# Altium schematic sheet digital_io.SchDoc
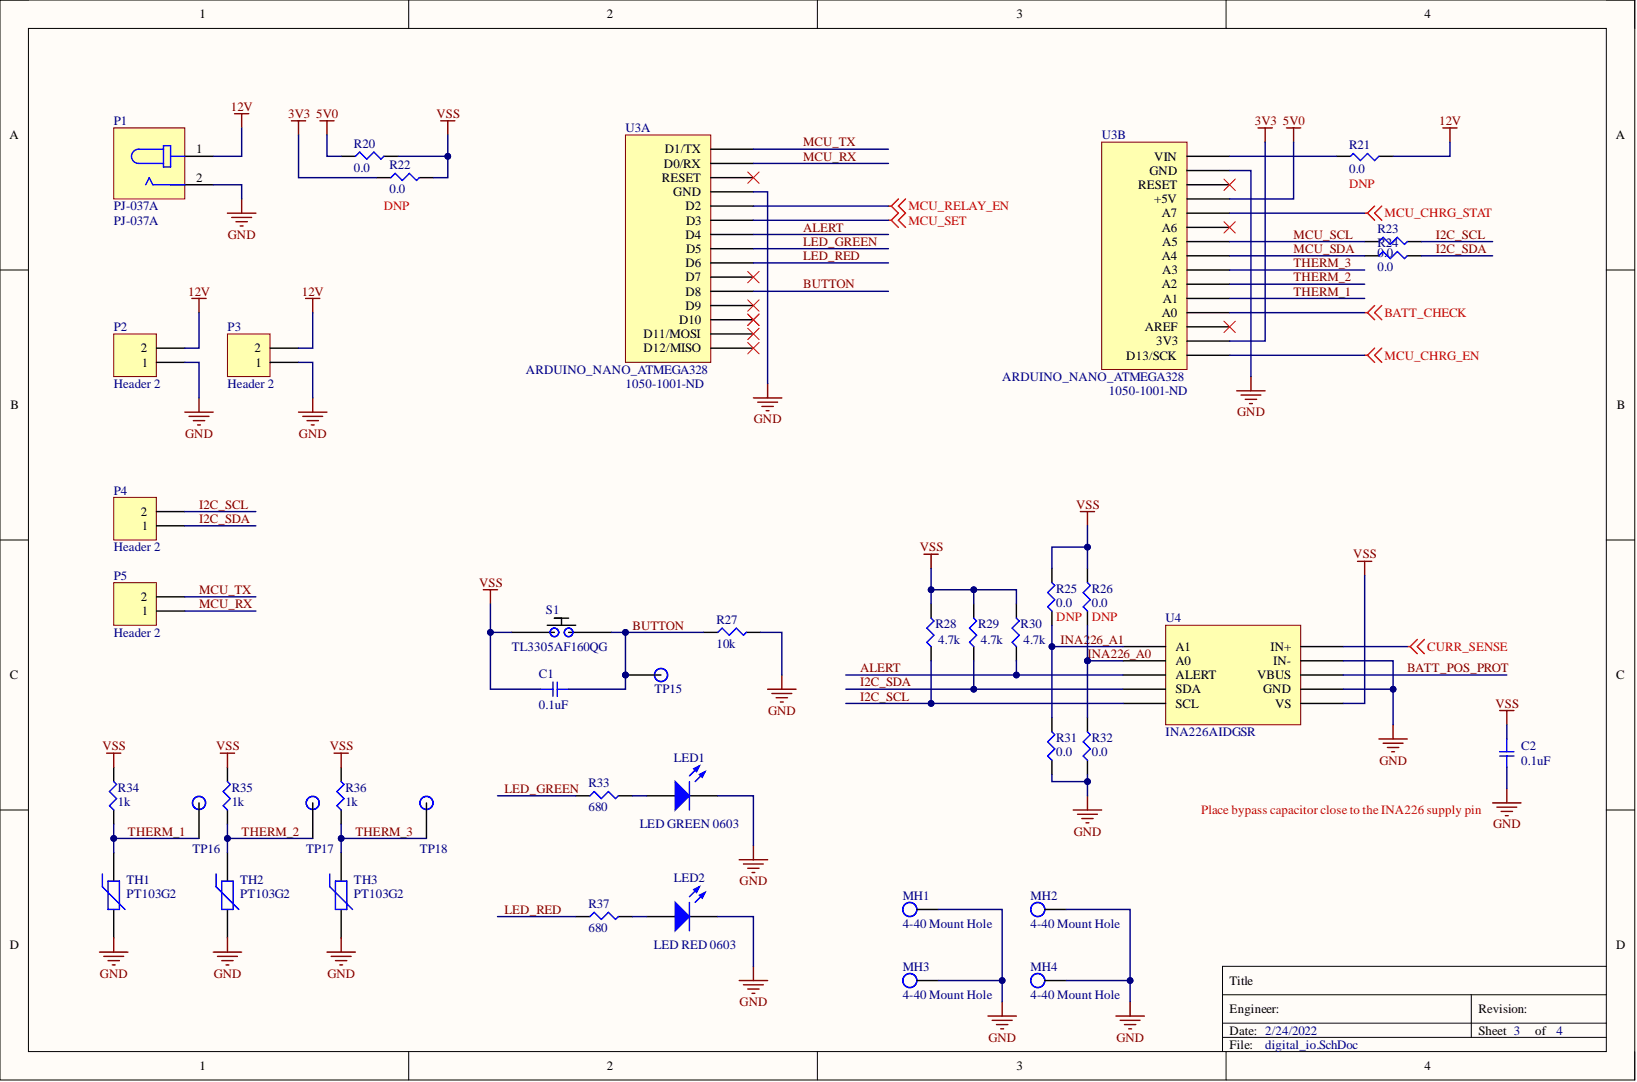
[diagram p0: digital_io.SchDoc]

## Parts
C1  0.1uF  [SMD-0805C]
C2  0.1uF  [SMD-0805C]
LED1  LED GREEN 0603  Color: GREEN  Package / Case: 0603  [LED 0603 GREEN]
LED2  LED RED 0603  Color: RED  Package / Case: 0603  [LED 0603 RED]
MH1  4-40 Mount Hole  [MH]
MH2  4-40 Mount Hole  [MH]
MH3  4-40 Mount Hole  [MH]
MH4  4-40 Mount Hole  [MH]
P1  PJ-037A  [CUI_PJ-037A]
P2  Header 2  [Pin Header 1x2 TH Pitch 2.54mm]
P3  Header 2  [Pin Header 1x2 TH Pitch 2.54mm]
P4  Header 2  [Pin Header 1x2 TH Pitch 2.54mm]
P5  Header 2  [Pin Header 1x2 TH Pitch 2.54mm]
R20  0.0  [SMD-0805-RES]
R21  0.0  [SMD-0805-RES]
R22  0.0  [SMD-0805-RES]
R23  0.0  [SMD-0805-RES]
R24  0.0  [SMD-0805-RES]
R25  0.0  [SMD-0805-RES]
R26  0.0  [SMD-0805-RES]
R27  10k  [SMD-0805-RES]
R28  4.7k  [SMD-0805-RES]
R29  4.7k  [SMD-0805-RES]
R30  4.7k  [SMD-0805-RES]
R31  0.0  [SMD-0805-RES]
R32  0.0  [SMD-0805-RES]
R33  680  [SMD-0805-RES]
R34  1k  [SMD-0805-RES]
R35  1k  [SMD-0805-RES]
R36  1k  [SMD-0805-RES]
R37  680  [SMD-0805-RES]
S1  TL3305AF160QG  [TL3305AF160QG]
TH1  PT103G2  [NTC_THERM_LEAD]
TH2  PT103G2  [NTC_THERM_LEAD]
TH3  PT103G2  [NTC_THERM_LEAD]
TP15  TP  [Test Point]
TP16  TP  [Test Point]
TP17  TP  [Test Point]
TP18  TP  [Test Point]
U3  ARDUINO_NANO_ATMEGA328  Supplier Part Number 1: 1050-1001-ND  [ARDUINO_NANO_ATMEGA328]  (parts A,B)
U4  INA226AIDGSR  [VSSOP10]

## Nets
3V3: R22.1 U3.2.14(3V3)
5V0: R20.1 U3.2.4(+5V)
12V: P1.1 P2.2 P3.2 R21.1
BATT_CHECK: U3.2.12(A0)
CURR_SENSE: U4.10(IN+)
GND: C2.2 LED1.2 LED2.2 MH1.1 MH2.1 MH3.1 MH4.1 P1.2 P2.1 P3.1 R27.2 R31.1 R32.1 TH1.2 TH2.2 TH3.2 U3.1.4(GND) U3.2.2(GND) U4.7(GND) U4.9(IN-)
MCU_CHRG_EN: U3.2.15(D13/SCK)
MCU_CHRG_STAT: U3.2.5(A7)
MCU_RELAY_EN: U3.1.5(D2)
MCU_SET: U3.1.6(D3)
VSS: C1.1 C2.1 R20.2 R22.2 R25.2 R26.2 R28.2 R29.2 R30.2 R34.2 R35.2 R36.2 S1.1 U4.6(VS)
ALERT: R30.1 U3.1.7(D4) U4.3(ALERT)
BATT_POS_PROT: U4.8(VBUS)
BUTTON: C1.2 R27.1 S1.2 TP15.1 U3.1.11(D8)
I2C_SCL: P4.2 R23.2 R28.1 U4.5(SCL)
I2C_SDA: P4.1 R24.2 R29.1 U4.4(SDA)
INA226_A0: R26.1 R32.2 U4.2(A0)
INA226_A1: R25.1 R31.2 U4.1(A1)
LED_GREEN: R33.1 U3.1.8(D5)
LED_RED: R37.1 U3.1.9(D6)
MCU_RX: P5.1 U3.1.2(D0/RX)
MCU_SCL: R23.1 U3.2.7(A5)
MCU_SDA: R24.1 U3.2.8(A4)
MCU_TX: P5.2 U3.1.1(D1/TX)
THERM_1: R34.1 TH1.1 TP16.1 U3.2.11(A1)
THERM_2: R35.1 TH2.1 TP17.1 U3.2.10(A2)
THERM_3: R36.1 TH3.1 TP18.1 U3.2.9(A3)
NetLED1_1: LED1.1 R33.2
NetLED2_1: LED2.1 R37.2
NetR21_2: R21.2 U3.2.1(VIN)

Not connected: U3 1.3(RESET) 1.10(D7) 1.12(D9) 1.13(D10) 1.14(D11/MOSI) 1.15(D12/MISO) 2.3(RESET) 2.6(A6) 2.13(AREF)

## Library data of the parts
C1 C2 = CGA4J3X7T2E104K125AA: Cap Ceramic 0.1uF 250V X7T 10% SMD 0805 125°C Blister Plastic T/R; Manufacturer: TDK; Capacitance: 100nF; Case Code (Imperial): 0805; Case Code (Metric): 2012; Case/Package: 0805; Category: Ceramic Capacitors; Component Kind: Standard; ComponentLink1Description: https://datasheet.ciiva.com/22703/1807242-22703721.pdf?src-supplier=Element14; ComponentLink1URL: https://datasheet.ciiva.com/22703/1807242-22703721.pdf?src-supplier=Element14; Construction: Flat; Depth: 1.25mm; Dielectric: X7T; Height: 1.25mm; Length: 2mm; Library Name: capacitors.SchLib; Manufacturer Part Number: CGA4J3X7T2E104K125AA; Max Operating Temperature: 125°C; Min Operating Temperature: -55°C; Mount: Surface Mount; Package Quantity: 2000; Packaging: Tape and Reel; Pricing 1: 1=0.33000, 10=0.22600, 50=0.15820, 100=0.13560, 500=0.10172, 1000=0.08590 (USD); Radiation Hardening: No; Ratings: AEC-Q200; REACH SVHC: No SVHC; Resistor Type: Ceramic; RoHS: Yes; RoHS Compliant: Yes; Series: CGA; Stock 1: 335314; Supplier 1: Digi-Key; Supplier Part Number 1: 0.1uF; Termination: SMD/SMT; Thickness: 0.057inch; Tolerance: 10%; Value: 0.1uF; Voltage Rating: 250V; Voltage Rating (DC): 250V; Weight: 0.000194oz; Width: 1.25mm
LED1 = LED GREEN 0603: Manufacturer: Lite-On Inc.; Manufacturer P/N: LTST-C190KGKT; Component Kind: Standard; Digikey P/N: 160-1435-1-ND; Library Name: misc.SchLib
LED2 = LED RED 0603: Red 631nm LED Indication - Discrete 2V 0603 (1608 Metric); Manufacturer: Lite-On Inc.; Manufacturer P/N: LTST-C190KRKT; Component Kind: Standard; Digikey P/N: 160-1436-1-ND; Library Name: misc.SchLib
P1 = PJ-037A: CONN PWR JACK 2X5.5MM SOLDER; Manufacturer: CUI Devices; Component Kind: Standard; Library Name: connector.SchLib; Manufacturer P/N: PJ-037A; Supplier: Digi-Key; Supplier P/N: CP-037A-ND
P2-P5 = Header 2: Header, 2-Pin; Component Kind: Standard; LatestRevisionDate: 17-Jul-2002; LatestRevisionNote: Re-released for DXP Platform.; Library Name: connector.SchLib; Signal Integrity: Connector; Publisher: Altium Limited
R20-R26 R31 R32 = ERJ-6GEY0R00V: RES SMD 0.0 OHM JUMPER 1/8W 0805; Manufacturer: Panasonic Electronic Components; @ qty: 1500; Factory Stock: 0; Minimum Quantity: 1; Power (Watts): 0.125W, 1/8W; Unit Price (USD): 0.00544; Component Kind: Standard; Composition: Thick Film; Datasheets: http://industrial.panasonic.com/www-cgi/jvcr13pz.cgi?E+PZ+3+AOA0001+ERJ6GEY0R00V+7+WW; Digi-Key Part Number: P0.0ACT-ND; Failure Rate: -; Features: Automotive AEC-Q200; Height - Seated (Max): 0.028" (0.70mm); Image: //media.digikey.com/Renders/Panasonic%20Renders/ERJ-0805%20Pkg.jpg; Library Name: resistors.SchLib; Number of Terminations: 2; Operating Temperature: -55°C ~ 155°C; Package / Case: 0805 (2012 Metric); Packaging: Cut Tape (CT); Part Number: ERJ-6GEY0R00V; Part Status: Active; Quantity Available: 1597627; Series: ERJ; Size / Dimension: 0.079" L x 0.049" W (2.00mm x 1.25mm); Supplier Device Package: 0805; Temperature Coefficient: -; Tolerance: Jumper; Value: 0.0
  R21 R22 R25 R26: DNP: DNP
R27 = ERJ-6GEYJ103V: RES SMD 10K OHM 5% 1/8W 0805; Manufacturer: Panasonic Electronic Components; @ qty: 1500; Factory Stock: 0; Minimum Quantity: 1; Power (Watts): 0.125W, 1/8W; Temperature Coefficient: ±200ppm/°C; Unit Price (USD): 0.00496; Component Kind: Standard; Composition: Thick Film; Datasheets: http://industrial.panasonic.com/www-cgi/jvcr13pz.cgi?E+PZ+3+AOA0001+ERJ6GEYJ103V+7+WW; Digi-Key Part Number: P10KACT-ND; Failure Rate: -; Features: Automotive AEC-Q200; Height - Seated (Max): 0.028" (0.70mm); Image: //media.digikey.com/Renders/Panasonic%20Renders/ERJ-0805%20Pkg.jpg; Library Name: resistors.SchLib; Number of Terminations: 2; Operating Temperature: -55°C ~ 155°C; Package / Case: 0805 (2012 Metric); Packaging: Cut Tape (CT); Part Number: ERJ-6GEYJ103V; Part Status: Active; Quantity Available: 2328224; Series: ERJ; Size / Dimension: 0.079" L x 0.049" W (2.00mm x 1.25mm); Supplier Device Package: 0805; Tolerance: ±5%; Value: 10k
R28-R30 = ERJ-P06J472V: RES SMD 4.7K OHM 5% 1/2W 0805; Manufacturer: Panasonic Electronic Components; @ qty: 1500; Factory Stock: 0; Minimum Quantity: 1; Power (Watts): 0.5W, 1/2W; Temperature Coefficient: ±200ppm/°C; Unit Price (USD): 0.01839; Component Kind: Standard; Composition: Thick Film; Datasheets: https://industrial.panasonic.com/cdbs/www-data/pdf/RDA0000/AOA0000C243.pdf; Digi-Key Part Number: P4.7KADCT-ND; Failure Rate: -; Features: Automotive AEC-Q200, Pulse Withstanding; Height - Seated (Max): 0.028" (0.70mm); Image: //media.digikey.com/Renders/Panasonic%20Renders/ERJ-0805%20Pkg.jpg; Library Name: resistors.SchLib; Number of Terminations: 2; Operating Temperature: -55°C ~ 155°C; Package / Case: 0805 (2012 Metric); Packaging: Cut Tape (CT); Part Number: ERJ-P06J472V; Part Status: Active; Quantity Available: 34864; Series: ERJ; Size / Dimension: 0.079" L x 0.049" W (2.00mm x 1.25mm); Supplier Device Package: 0805; Tolerance: ±5%; Value: 4.7k
R33 R37 = ERJ-6GEYJ681V: RES SMD 680 OHM 5% 1/8W 0805; Manufacturer: Panasonic Electronic Components; @ qty: 1500; Factory Stock: 0; Minimum Quantity: 1; Power (Watts): 0.125W, 1/8W; Temperature Coefficient: ±200ppm/°C; Unit Price (USD): 0.00496; Component Kind: Standard; Composition: Thick Film; Datasheets: http://industrial.panasonic.com/www-cgi/jvcr13pz.cgi?E+PZ+3+AOA0001+ERJ6GEYJ681V+7+WW; Digi-Key Part Number: P680ACT-ND; Failure Rate: -; Features: Automotive AEC-Q200; Height - Seated (Max): 0.028" (0.70mm); Image: //media.digikey.com/Renders/Panasonic%20Renders/ERJ-0805%20Pkg.jpg; Library Name: resistors.SchLib; Number of Terminations: 2; Operating Temperature: -55°C ~ 155°C; Package / Case: 0805 (2012 Metric); Packaging: Cut Tape (CT); Part Number: ERJ-6GEYJ681V; Part Status: Active; Quantity Available: 65877; Series: ERJ; Size / Dimension: 0.079" L x 0.049" W (2.00mm x 1.25mm); Supplier Device Package: 0805; Tolerance: ±5%; Value: 680
R34-R36 = ERJ-6ENF1001V: RES SMD 1K OHM 1% 1/8W 0805; Manufacturer: Panasonic Electronic Components; @ qty: 1500; Factory Stock: 0; Minimum Quantity: 1; Power (Watts): 0.125W, 1/8W; Temperature Coefficient: ±100ppm/°C; Unit Price (USD): 0.00729; Component Kind: Standard; Composition: Thick Film; Datasheets: http://industrial.panasonic.com/www-cgi/jvcr13pz.cgi?E+PZ+3+AOA0002+ERJ6ENF1001V+7+WW; Digi-Key Part Number: P1.00KCCT-ND; Failure Rate: -; Features: Automotive AEC-Q200; Height - Seated (Max): 0.028" (0.70mm); Image: //media.digikey.com/Renders/Panasonic%20Renders/ERJ-0805%20Pkg.jpg; Library Name: resistors.SchLib; Number of Terminations: 2; Operating Temperature: -55°C ~ 155°C; Package / Case: 0805 (2012 Metric); Packaging: Cut Tape (CT); Part Number: ERJ-6ENF1001V; Part Status: Active; Quantity Available: 12895103; Series: ERJ; Size / Dimension: 0.079" L x 0.049" W (2.00mm x 1.25mm); Supplier Device Package: 0805; Tolerance: ±1%; Value: 1k
S1 = TL3305AF160QG: SWITCH TACTILE SPST-NO 50MA 12V; Manufacturer: E-Switch; Switch Function: Off-Mom; Component Kind: Standard; ComponentLink1Description: https://www.digikey.com/en/products/detail/e-switch/TL3305AF160QG/5816181; ComponentLink1URL: https://www.digikey.com/en/products/detail/e-switch/TL3305AF160QG/5816181; ComponentLink2Description: https://sten-eswitch-13110800-production.s3.amazonaws.com/system/asset/product_line/data_sheet/213/TL3305.pdf; ComponentLink2URL: https://sten-eswitch-13110800-production.s3.amazonaws.com/system/asset/product_line/data_sheet/213/TL3305.pdf; Library Name: misc.SchLib; Manufacturer Part Number: TL3305AF160QG; Mount: Surface Mount; Supplier 1: Digi-Key; Termination: SMD/SMT; Throw Configuration: SPST-NO
TH1-TH3 = PT103G2: THERMISTOR NTC 10KOHM 3575K BEAD; Manufacturer: Littelfuse Inc.; Resistance: 10k; Component Kind: Standard; ComponentLink1Description: https://www.digikey.com/en/products/detail/littelfuse-inc/PT103G2/2242426; ComponentLink1URL: https://www.digikey.com/en/products/detail/littelfuse-inc/PT103G2/2242426; Library Name: misc.SchLib; Manufacturer Part Number: PT103G2; Supplier 1: Mouser; Supplier 2: Digi-Key; Supplier Part Number 2: F11789-ND; Termination: Lead
TP15-TP18 = TP: SMD TEST POINT; Component Kind: Standard; Library Name: misc.SchLib
U3 = ARDUINO_NANO_ATMEGA328: ATmega328 Arduino Nano series AVR MCU 8-Bit Embedded Evaluation Board; Manufacturer Part Number: A000005; Evaluation Kit: Yes; Number of Bits: 8; Component Kind: Standard; ComponentLink8Description: http://ww1.microchip.com/downloads/en/DeviceDoc/ATmega48A-PA-88A-PA-168A-PA-328-P-DS-DS40002061A.pdf; ComponentLink8URL: http://ww1.microchip.com/downloads/en/DeviceDoc/ATmega48A-PA-88A-PA-168A-PA-328-P-DS-DS40002061A.pdf; ComponentLink9Description: https://media.digikey.com/pdf/Data%20Sheets/Arduino%20PDFs/A000005.pdf; ComponentLink9URL: https://media.digikey.com/pdf/Data%20Sheets/Arduino%20PDFs/A000005.pdf; Core Architecture: AVR; Lead Free: Lead Free; Library Name: ic.SchLib; Manufacturer: Arduino; Pricing 1: 1=20.70 (USD); RoHS: Yes; Supplier 1: Digi-Key
U4 = INA226AIDGSR: IC MONITOR PWR/CURR BIDIR 10MSOP; Manufacturer: Texas Instruments; Component Kind: Standard; ComponentLink1Description: https://www.ti.com/lit/ds/symlink/ina226.pdf?ts=1642776332750&ref_url=https%253A%252F%252Fwww.google.com%252F; ComponentLink1URL: https://www.ti.com/lit/ds/symlink/ina226.pdf?ts=1642776332750&ref_url=https%253A%252F%252Fwww.google.com%252F; Library Name: ic.SchLib; Manufacturer Part Number: INA226AIDGSR; Pricing 1: 1=3.54 (USD); RoHS: Yes; Supplier 1: Digi-Key; Supplier Part Number 1: 296-29034-1-ND
MH1-MH4 = 4-40 Mount Hole: Component Kind: Standard; Library Name: misc.SchLib
